# Altium schematic sheet LazeRX-Mini 1.5.sch("LazeRX-Mini 1.5")
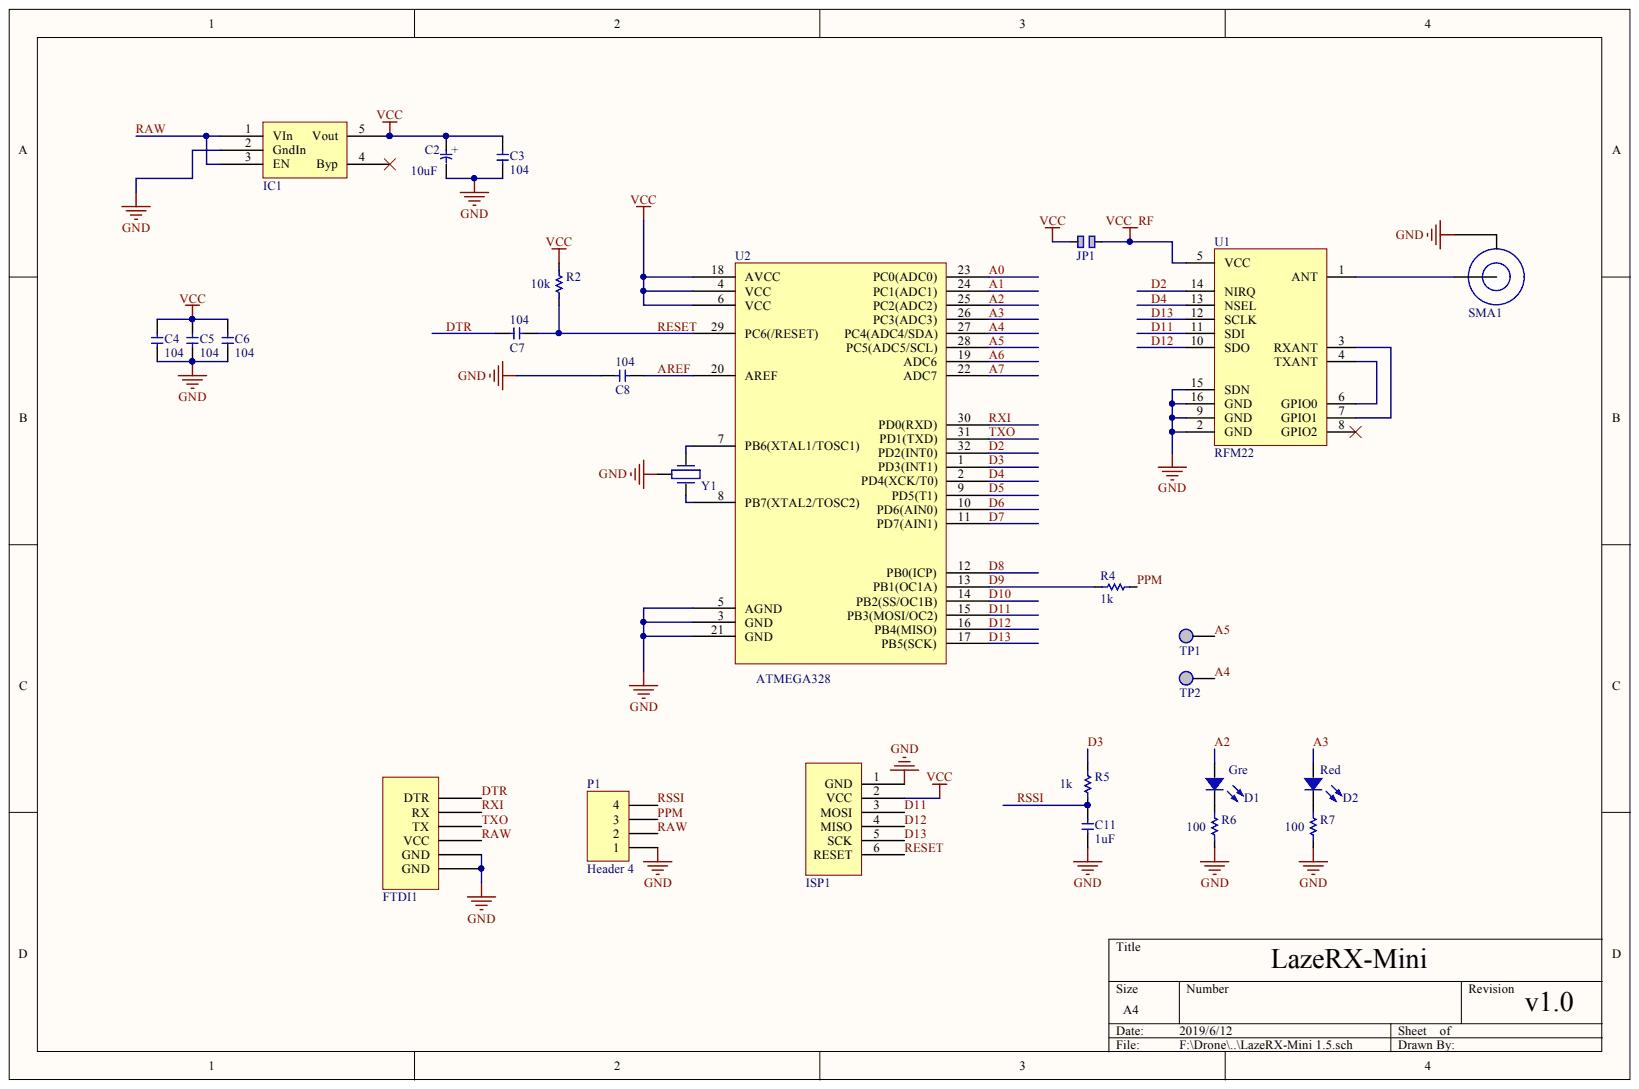
[diagram p0: LazeRX-Mini 1.5.sch("LazeRX-Mini 1.5")]

## Parts
C2  10uF  [1206 CAP]
C3  104  [0603 CAP]
C4  104  [0603 CAP]
C5  104  [0603 CAP]
C6  104  [0603 CAP]
C7  104  [0603 CAP]
C8  104  [0603 CAP]
C11  1uF  [0603 CAP]
D1  Gre  [0805 LED]
D2  Red  [0805 LED]
FTDI1  AVR FTDI 1.27  [127ISP]  (value not drawn)
IC1  5205  [5205]  (value not drawn)
ISP1  AVR ISP 1.27  [127ISP]  (value not drawn)
JP1  SJ  [SJ]  (value not drawn)
P1  Header 4  [HDR1X4]
R2  10k  [0603 RES]
R4  1k  [0603 RES]
R5  1k  [0603 RES]
R6  100  [0603 RES]
R7  100  [0603 RES]
SMA1  SMA Base  [ANT SMA]  (value not drawn)
TP1  Test Pad  [Test Pad 1.2MM]  (value not drawn)
TP2  Test Pad  [Test Pad 1.2MM]  (value not drawn)
U1  RFM22  [RFM22SMD]
U2  ATmega328  [ATMEGA328FIX]  (value not drawn)
Y1  Crystal3Foot  [Crystal3Foot]  (value not drawn)

## Nets
GND: C2.2 C3.1 C4.1 C5.1 C6.1 C8.1 C11.1 FTDI1.5(GND) FTDI1.6(GND) IC1.2(GndIn) ISP1.1(GND) P1.1 R6.1 R7.1 SMA1.2 U1.2(GND) U1.9(GND) U1.15(SDN) U1.16(GND) U2.3(GND) U2.5(AGND) U2.21(GND) Y1.2
VCC: C2.1 C3.2 C4.2 C5.2 C6.2 IC1.5(Vout) ISP1.2(VCC) JP1.1 R2.2 U2.4(VCC) U2.6(VCC) U2.18(AVCC)
VCC_RF: JP1.2 U1.5(VCC)
A0: U2.23(PC0(ADC0))
A1: U2.24(PC1(ADC1))
A2: D1.1 U2.25(PC2(ADC2))
A3: D2.1 U2.26(PC3(ADC3))
A4: TP2.1 U2.27(PC4(ADC4/SDA))
A5: TP1.1 U2.28(PC5(ADC5/SCL))
A6: U2.19(ADC6)
A7: U2.22(ADC7)
AREF: C8.2 U2.20(AREF)
D2: U1.14(NIRQ) U2.32(PD2(INT0))
D3: R5.2 U2.1(PD3(INT1))
D4: U1.13(NSEL) U2.2(PD4(XCK/T0))
D5: U2.9(PD5(T1))
D6: U2.10(PD6(AIN0))
D7: U2.11(PD7(AIN1))
D8: U2.12(PB0(ICP))
D9: R4.2 U2.13(PB1(OC1A))
D10: U2.14(PB2(SS/OC1B))
D11: ISP1.3(MOSI) U1.11(SDI) U2.15(PB3(MOSI/OC2))
D12: ISP1.4(MISO) U1.10(SDO) U2.16(PB4(MISO))
D13: ISP1.5(SCK) U1.12(SCLK) U2.17(PB5(SCK))
DTR: C7.1 FTDI1.1(DTR)
PPM: P1.3 R4.1
RAW: FTDI1.4(VCC) IC1.1(VIn) IC1.3(EN) P1.2
RESET: C7.2 ISP1.6(RESET) R2.1 U2.29(PC6(/RESET))
RSSI: C11.2 P1.4 R5.1
RXI: FTDI1.2(RX) U2.30(PD0(RXD))
TXO: FTDI1.3(TX) U2.31(PD1(TXD))
NetD1_2: D1.2 R6.2
NetD2_2: D2.2 R7.2
NetSMA1_1: SMA1.1 U1.1(ANT)
NetU1_3: U1.3(RXANT) U1.7(GPIO1)
NetU1_4: U1.4(TXANT) U1.6(GPIO0)
NetU2_7: U2.7(PB6(XTAL1/TOSC1)) Y1.1
NetU2_8: U2.8(PB7(XTAL2/TOSC2)) Y1.3

Not connected: IC1 4(Byp); U1 8(GPIO2)

## Library data of the parts
C2 = Cap Pol: Capacitor; Component Kind: Standard; Library Name: Drone.IntLib
C3-C8 C11 = CAP: Capacitor; Value: 100nF; Component Kind: Standard; Published: 8-Jun-2000; Revision: July-2002: Re-released for DXP Platform.; Library Name: Drone.IntLib; Publisher: Altium Limited
D1 D2 = LED: Typical RED, GREEN, YELLOW, AMBER GaAs LED; Component Kind: Standard; LatestRevisionDate: 17-Jul-2002; LatestRevisionNote: Re-released for DXP Platform.; PackageDocument: Feb-2002; PackageReference: 3.2X1.6X1.1; Published: 8-Jun-2000; Library Name: Drone.IntLib; Publisher: Altium Limited
P1 = Header 4: Header, 4-Pin; Component Kind: Standard; LatestRevisionDate: 17-Jul-2002; LatestRevisionNote: Re-released for DXP Platform.; Library Name: Miscellaneous Connectors.IntLib; Signal Integrity: Connector; Publisher: Altium Limited
R2 R4-R7 = Res: Resistor; Component Kind: Standard; Published: 8-Jun-2000; Revision: July-2002: Re-released for DXP Platform.; Library Name: Drone.IntLib; Publisher: Altium Limited
FTDI1 = AVR FTDI 1.27: Component Kind: Standard; Library Name: Drone.IntLib
IC1 = 5205: Component Kind: Standard; Library Name: Drone.IntLib
ISP1 = AVR ISP 1.27: Component Kind: Standard; Library Name: Drone.IntLib
JP1 = SJ: Component Kind: Standard; Library Name: Drone.IntLib
SMA1 = SMA Base: Component Kind: Standard; Library Name: Drone.IntLib
TP1 TP2 = Test Pad: Component Kind: Standard; Library Name: Drone.IntLib
U1 = RFM22: Component Kind: Standard; Library Name: Drone.IntLib
U2 = ATmega328: Component Kind: Standard; Library Name: Drone.IntLib
Y1 = Crystal3Foot: Component Kind: Standard; Library Name: Drone.IntLib
